# Altium schematic sheet AMRadio.SchDoc(AMRadio)
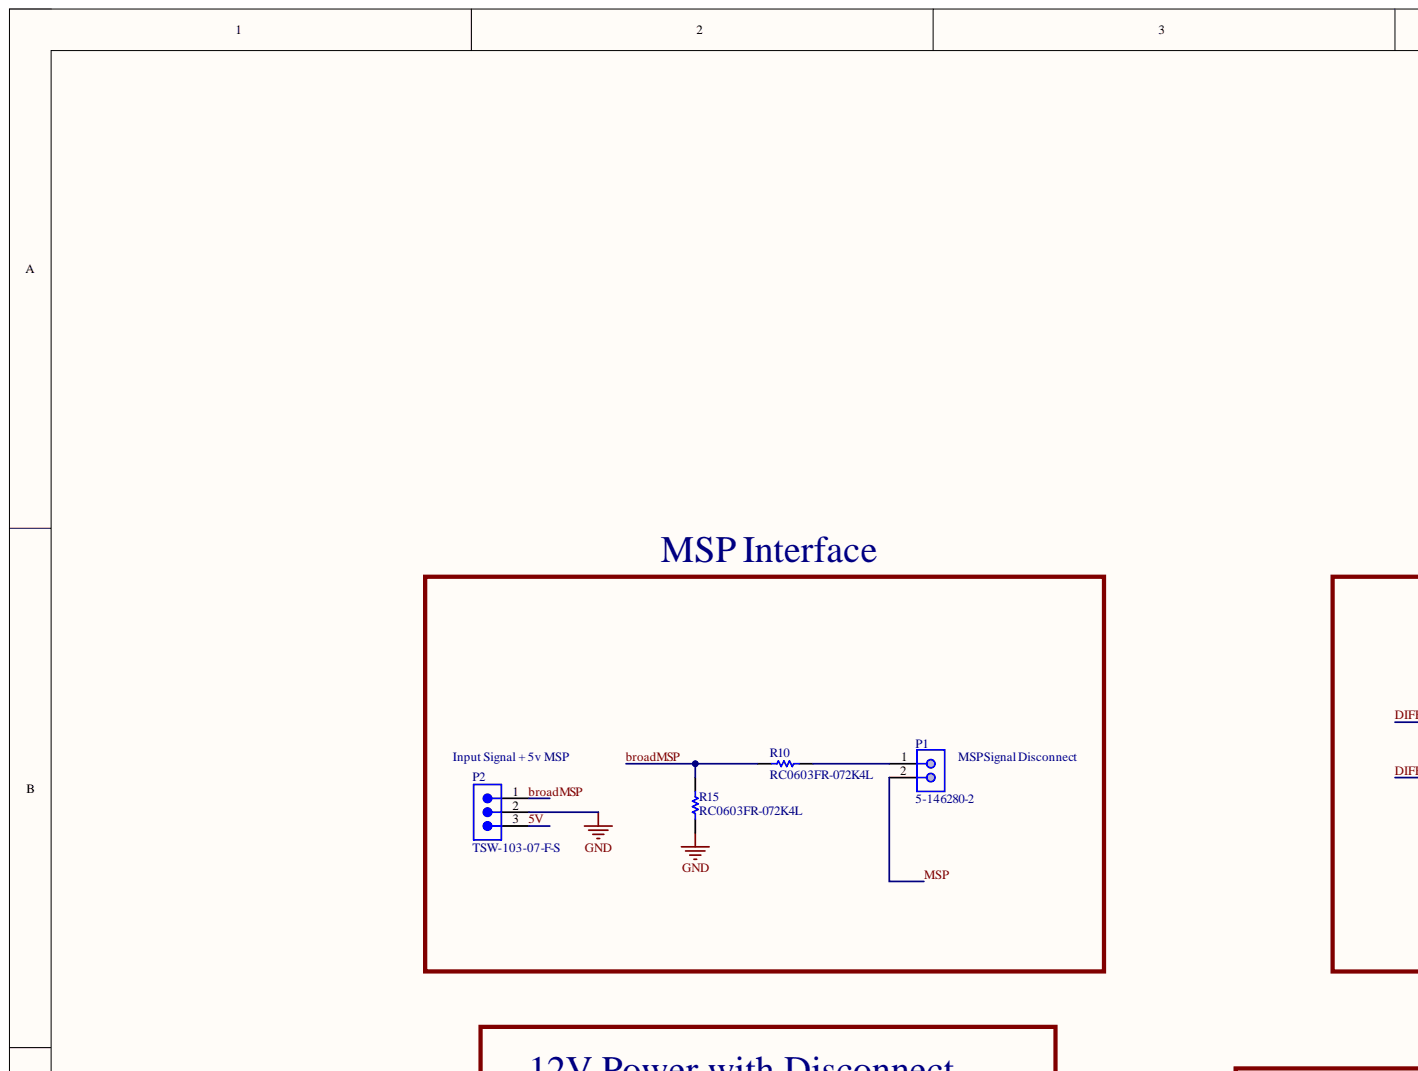
[diagram p0: AMRadio.SchDoc, top left]
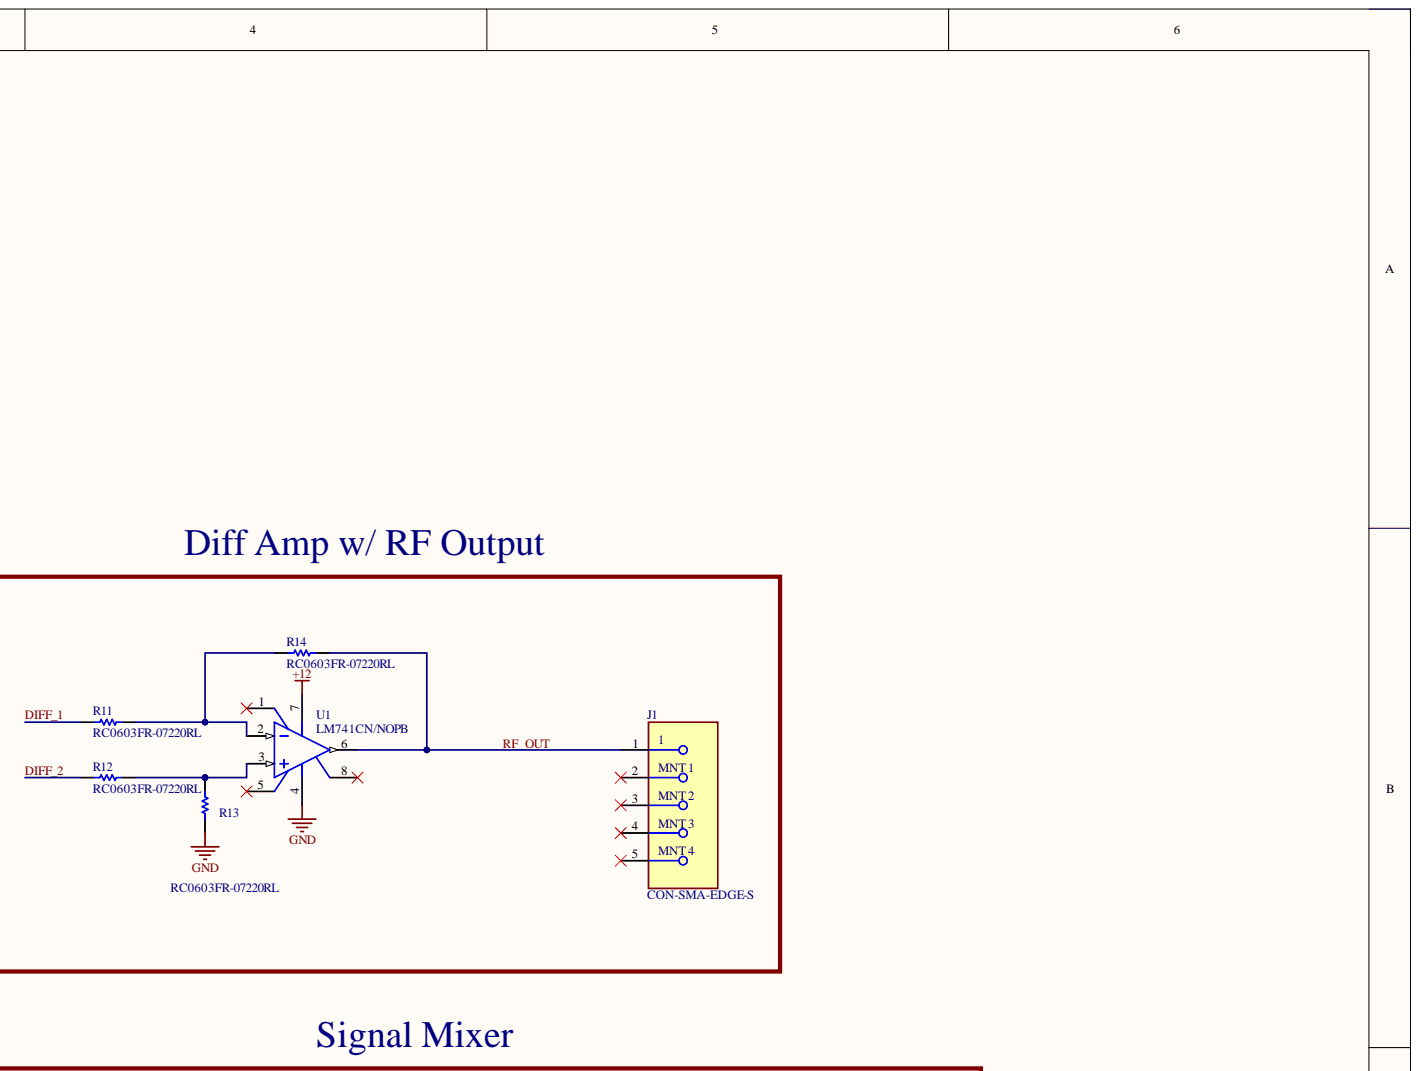
[diagram p1: AMRadio.SchDoc, top right]
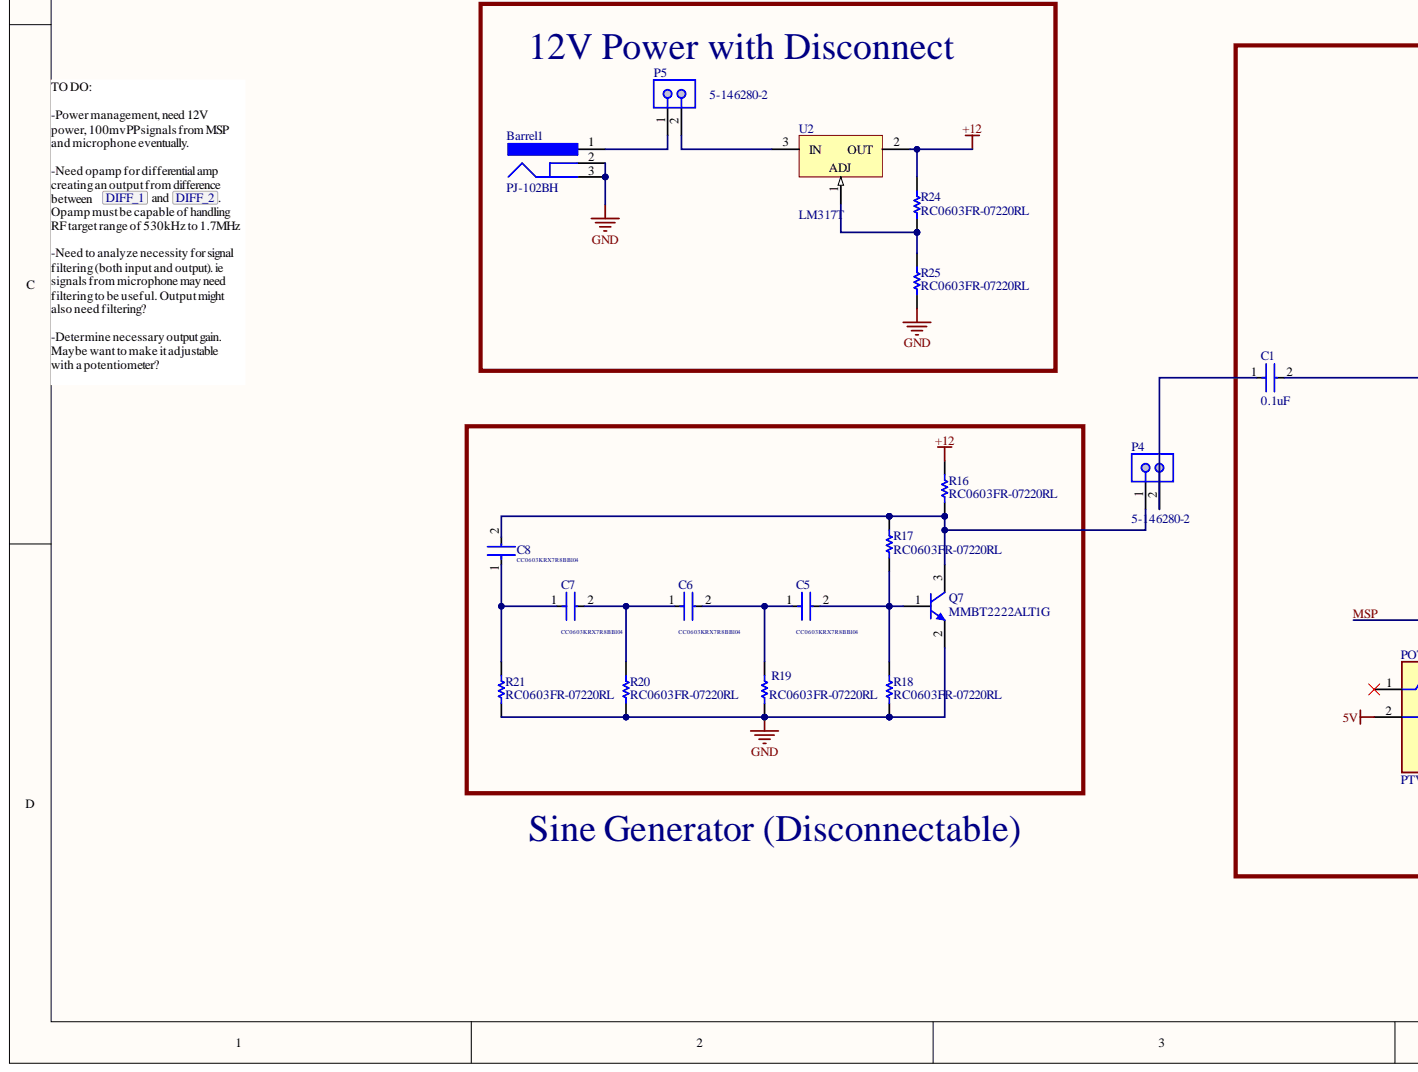
[diagram p2: AMRadio.SchDoc, bottom left]
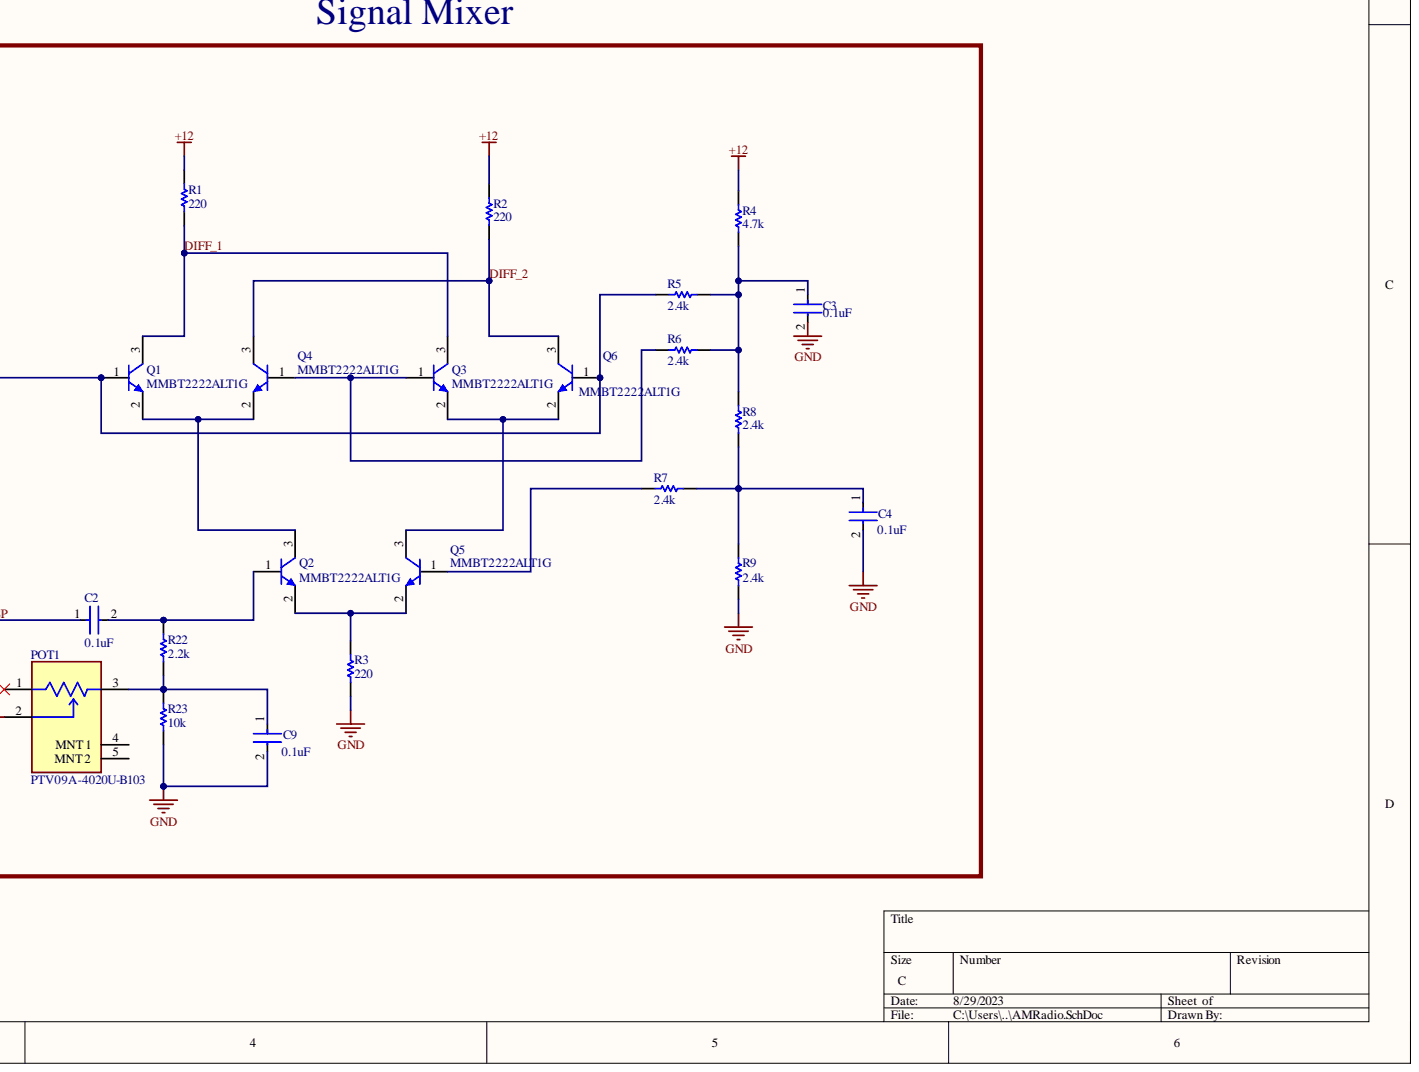
[diagram p3: AMRadio.SchDoc, bottom right]

## Parts
Barrel1  PJ-102BH  [CUI-PJ-102BH_V]
C1  0.1uF  [FP-CC0603-DA-MFG]
C2  0.1uF  [FP-CC0603-DA-MFG]
C3  0.1uF  [FP-CC0603-DA-MFG]
C4  0.1uF  [FP-CC0603-DA-MFG]
C5  CC0603KRX7R8BB104  [FP-CC0603-DA-MFG]
C6  CC0603KRX7R8BB104  [FP-CC0603-DA-MFG]
C7  CC0603KRX7R8BB104  [FP-CC0603-DA-MFG]
C8  CC0603KRX7R8BB104  [FP-CC0603-DA-MFG]
C9  0.1uF  [FP-CC0603-DA-MFG]
J1  CON-SMA-EDGE-S  [FP-CON-SMA-EDGE-S-MFG]
P1  5-146280-2  [TECO-5-146280-2_V]
P2  TSW-103-07-F-S  [SMTC-TSW-103-07-X-S]
P4  5-146280-2  [TECO-5-146280-2_V]
P5  5-146280-2  [TECO-5-146280-2_V]
POT1  PTV09A-4020U-B103  [FP-PTV09A-4-MFG]
Q1  MMBT2222ALT1G  [ONSC-SOT-23-3-318-08_V]
Q2  MMBT2222ALT1G  [ONSC-SOT-23-3-318-08_V]
Q3  MMBT2222ALT1G  [ONSC-SOT-23-3-318-08_V]
Q4  MMBT2222ALT1G  [ONSC-SOT-23-3-318-08_V]
Q5  MMBT2222ALT1G  [ONSC-SOT-23-3-318-08_V]
Q6  MMBT2222ALT1G  [ONSC-SOT-23-3-318-08_V]
Q7  MMBT2222ALT1G  [ONSC-SOT-23-3-318-08_V]
R1  220  [RESC1608X55X25ML10T15]
R2  220  [RESC1608X55X25ML10T15]
R3  220  [RESC1608X55X25ML10T15]
R4  4.7k  [RESC1608X55X25LL10T15]
R5  2.4k  [RESC1608X55X25LL10T15]
R6  2.4k  [RESC1608X55X25LL10T15]
R7  2.4k  [RESC1608X55X25LL10T15]
R8  2.4k  [RESC1608X55X25LL10T15]
R9  2.4k  [RESC1608X55X25LL10T15]
R10  RC0603FR-072K4L  [RESC1608X55X25LL10T15]
R11  RC0603FR-07220RL  [RESC1608X55X25ML10T15]
R12  RC0603FR-07220RL  [RESC1608X55X25ML10T15]
R13  RC0603FR-07220RL  [RESC1608X55X25ML10T15]
R14  RC0603FR-07220RL  [RESC1608X55X25ML10T15]
R15  RC0603FR-072K4L  [RESC1608X55X25LL10T15]
R16  RC0603FR-07220RL  [RESC1608X55X25ML10T15]
R17  RC0603FR-07220RL  [RESC1608X55X25ML10T15]
R18  RC0603FR-07220RL  [RESC1608X55X25ML10T15]
R19  RC0603FR-07220RL  [RESC1608X55X25ML10T15]
R20  RC0603FR-07220RL  [RESC1608X55X25ML10T15]
R21  RC0603FR-07220RL  [RESC1608X55X25ML10T15]
R22  2.2k  [RESC1608X55X25ML10T15]
R23  10k  [RESC1608X55X25ML10T15]
R24  RC0603FR-07220RL  [RESC1608X55X25ML10T15]
R25  RC0603FR-07220RL  [RESC1608X55X25ML10T15]
U1  LM741CN/NOPB  [P0008A]
U2  LM317T  [TO-220]

## Nets
+12: R1.1 R2.1 R4.2 R16.1 R24.1 U1.7 U2.2
GND: Barrel1.2 Barrel1.3 C3.2 C4.2 C9.2 P2.2 Q7.2 R3.2 R9.2 R13.2 R15.1 R18.2 R19.2 R20.2 R21.2 R23.2 R25.2 U1.4
5V: P2.3 POT1.2
broadMSP: P2.1 R10.2 R15.2
DIFF_1: Q1.3 Q3.3 R1.2 R11.1
DIFF_2: Q4.3 Q6.3 R2.2 R12.1
MSP: C2.1 P1.2
RF_OUT: J1.1 R14.2 U1.6
NetBarrel1_1: Barrel1.1 P5.1
NetC1_1: C1.1 P4.2
NetC1_2: C1.2 Q1.1 Q6.1 R5.1
NetC2_2: C2.2 Q2.1 R22.1
NetC3_1: C3.1 R4.1 R5.2 R6.2 R8.1
NetC4_1: C4.1 R7.2 R8.2 R9.1
NetC5_1: C5.1 C6.2 R19.1
NetC5_2: C5.2 Q7.1 R17.2 R18.1
NetC6_1: C6.1 C7.2 R20.1
NetC7_1: C7.1 C8.1 R21.1
NetC8_2: C8.2 P4.1 Q7.3 R16.2 R17.1
NetC9_1: C9.1 POT1.3 R22.2 R23.1
NetP1_1: P1.1 R10.1
NetP5_2: P5.2 U2.3
NetQ1_2: Q1.2 Q2.3 Q4.2
NetQ2_2: Q2.2 Q5.2 R3.1
NetQ3_1: Q3.1 Q4.1 R6.1
NetQ3_2: Q3.2 Q5.3 Q6.2
NetQ5_1: Q5.1 R7.1
NetR11_2: R11.2 R14.1 U1.2
NetR12_2: R12.2 R13.1 U1.3
NetR24_2: R24.2 R25.1 U2.1

Not connected: J1 2 3 4 5; POT1 1 4(MNT_1) 5(MNT_2); U1 1 5 8

## Library data of the parts
Barrel1 = CMP-2000-06901-1: Through Hole Right Angle DC Power Jack, 5 A, 2.5 mm Center Pin, 3 Position, -25 to 85 degC, RoHS, Bulk; Manufacturer: CUIInc.; Brand: CUI; Current Rating: 5A; Inside Contact Diameter: 2.5mm; Insulation Resistance: 100MOhms; Outside Contact Diameter: 6.5mm; Voltage Rating: 24V; Component Kind: Standard; Connector Type: DCPowerJack; Contact Gender: Male; Contact Material: Copper; Contact Plating: Nickel; Datasheet URL: http://www.cui.com/product/resource/pj-102bh.pdf; Datasheet Version: Rev. 1.02, 05/2016; Factory Pack Quantity: 280; Flammability Rating: UL94V-0; Housing Material: PolybutyleneTerephthalate(PBT); Library Name: Altium Content Vault; Manufacturer URL: http://www.cui.com/; Mounting Angle: Right; Mounting Style: ThroughHole; Mounting Technology: ThroughHole; Operating Temperature Range: -25Cto+85C; Package Description: 3-Pin Through Hole Device, Body 14.4 x 9 mm, Height 11 mm; Package Reference: PJ-102BH; Product: Jacks; Product Category: DCPowerConnectors; RoHS: True; Termination Style: ThroughHole
C1-C4 C9 = CMP-03422-000234-1: CAP CER 0.1UF 25V X7R 0603; Manufacturer: YAGEO; Manufacturer Part Number: CC0603KRX7R8BB104; Capacitance: 100 nF; Material: Ceramic; Temperature Coefficient: 15%; Tolerance: 0.1; Value: 100 nF; Automotive: No; Category: Cap; Component Kind: Standard; ComponentLink2Description: Datasheet; ComponentLink2URL: http://www.yageo.com/documents/recent/UPY-GPHC_X7R_6.3V-to-50V_18.pdf; Device Class L1: Passive Components; Device Class L2: Capacitors; Device Class L3: Ceramic Capacitors; Digikey Description: CAP CER 0.1UF 25V X7R 0603; Footprint Url: http://www.yageo.com/exep/pages/download/literatures/UPY-C_GEN_24.pdf; Height: 0.9mm; Lead Free: Yes; Library Name: Altium Content Vault; Mouser Description: CC Series 0603 0.1 uF 25 V ±10% Tolerance X7R SMT Multilayer Ceramic Capacitor; Octopart: https://octopart.com/cc0603krx7r8bb104-yageo-8376534; Package: CC0603-DA; RoHS: TRUE; Temperature: -55°C to +125°C; Temperature Characteristic: X7R; Temperature Range High: +125°C; Temperature Range Low: -55°C; Voltage: 25 V; Voltage Rating: 25 V
C5-C8 = CMP-03422-000234-1: CAP CER 0.1UF 25V X7R 0603; Manufacturer: YAGEO; Capacitance: 100 nF; Material: Ceramic; Temperature Coefficient: 15%; Tolerance: 0.1; Value: 100 nF; Automotive: No; Category: Cap; Component Kind: Standard; ComponentLink2Description: Datasheet; ComponentLink2URL: http://www.yageo.com/documents/recent/UPY-GPHC_X7R_6.3V-to-50V_18.pdf; Device Class L1: Passive Components; Device Class L2: Capacitors; Device Class L3: Ceramic Capacitors; Digikey Description: CAP CER 0.1UF 25V X7R 0603; Footprint Url: http://www.yageo.com/exep/pages/download/literatures/UPY-C_GEN_24.pdf; Height: 0.9mm; Lead Free: Yes; Library Name: Altium Content Vault; Manufacturer Part Number: CC0603KRX7R8BB104; Mouser Description: CC Series 0603 0.1 uF 25 V ±10% Tolerance X7R SMT Multilayer Ceramic Capacitor; Octopart: https://octopart.com/cc0603krx7r8bb104-yageo-8376534; Package: CC0603-DA; RoHS: TRUE; Temperature: -55°C to +125°C; Temperature Characteristic: X7R; Temperature Range High: +125°C; Temperature Range Low: -55°C; Voltage: 25 V; Voltage Rating: 25 V
J1 = CMP-204357-000001-1: CONN SMA JACK STR EDGE MNT; Manufacturer: RF Solutions Ltd.; Conn Orientation: Right Angle; Frequency: 18GHz; Impedance: 50Ω; Is Female: yes; Number Of Contacts: 1; Shielding: true; Automotive: No; Category: Conn; Component Kind: Standard; ComponentLink2Description: Datasheet; ComponentLink2URL: https://www.rfsolutions.co.uk/downloads/1498648656DS-CON-EDGE-SMA-4.pdf; Contact Material: Gold; Device Class L1: Connectors; Device Class L2: RF / Coaxial Connectors; Device Class L3: unset; Digikey Description: CONN SMA JACK STR EDGE MNT; Footprint Url: https://forum.upverter.com/uploads/default/original/3X/b/e/be75b907af57498775929d6d9099689c472e0307.pdf; Height: 6.35mm; Lead Free: Yes; Library Name: Altium Content Vault; Manufacturer Part Number: CON-SMA-EDGE-S; Octopart: https://octopart.com/con-sma-edge-s-rf+solutions-70967450; Package: CONN_JACK; RoHS: TRUE
P1 P4 P5 = CMP-2000-07492-1: Male Header, Pitch 2.54 mm, 1 x 2 Position, Height 8.21 mm, Tail Length 3.05 mm, -65 to 105 degC, RoHS, Bulk; Manufacturer: TE Connectivity; Approvals: CSA; Board Standoff: No(Without); Case\/Package: 100; Current Rating: 3A; High Speed Serial Data Connector: No; High Temperature Housing: Yes; Mating Post Length: 0.23inch; Max Current Rating: 5A; Number of Contacts: 2; PCB Mount Retention: Without; PCB Mounting Orientation: Vertical; PCB Thickness: 0.063inch; Pitch: 2.54mm; Colour: Black; Component Kind: Standard; Connector Type: Header; Contact Gender: Male; Contact Material: CopperAlloy; Contact Mating Length: 0.23inch; Contact Plating: Matte; Contact Protection: No(Without); Contact Shape: Square; Datasheet URL: http://www.te.com/commerce/DocumentDelivery/DDEController?Action=showdoc&DocId=Customer+Drawing%7F146280%7FH1%7Fpdf%7FEnglish%7FENG_CD_146280_H1.pdf%7F5-146280-2; Datasheet Version: Rev. H1, 08/2016; Depth: 2.34mm; Flammability Rating: UL94V-0; Gasket: No(Without); Housing Colour: Black; Housing Material: Polymer; Keyed: No; Length: 4.67mm; Library Name: Altium Content Vault; Manufacturer URL: http://www.te.com/; Mating Alignment: No(Without); Mating Connector Lock: No(Without); Max Operating Temperature: 105degC; Min Operating Temperature: -65degC; Mount: ThroughHole; Mounting Technology: ThroughHole; Number of Positions: 2; Number of Rows: 1; Orientation: Straight; Package Description: Male Header, Pitch 2.54 mm, 1 x 2 Position, Height 8.21 mm, Tail Length 3.05 mm; Package Quantity: 500; Package Reference: 5-146280-2; Packaging: Carton; Panel Mount Retention: No(Without); Radiation Hardening: No; RoHSCompliant: true; Row Spacing: 2.54mm; Selectively Loaded: No(Without); Stabilizers: No(Without); Termination: ThroughHole; Termination Post Length: 0.12inch
P2 = CMP-1024-00331-1: 0.025" SQ Post Header, Through-hole, Vertical, -55 to 125 degC, 2.54 mm Pitch, 3-Pin, Male, RoHS; Manufacturer: Samtec; Pitch: 2.54 mm; Component Kind: Standard; ComponentLink1Description: Manufacturer URL; ComponentLink1URL: http://www.samtec.com; ComponentLink2Description: Datasheet; ComponentLink2URL: http://www.samtec.com/documents/webfiles/pdf/TSW_TH.PDF; ComponentLink3Description: Package Specification; ComponentLink3URL: http://www.samtec.com/documents/webfiles/cpdf/TSW-XXX-XX-XXX-X-XX-XXX-MKT.pdf; DatasheetVersion: F-214; Library Name: Altium Content Vault; Mounting Technology: Through-hole; Orientation: Vertical; PackageDescription: .025[0.64] SQ. Post Terminal Strip Assembly; PackageReference: TSW-103-07-X-S; PackageVersion: DM, 8/1988; PartNumber: TSW-103-07-F-S; RoHS: True; Temperature Range: -55 to 125 degC
POT1 = CMP-07234-000013-1: POT 10K OHM 1/20W CARBON LINEAR; Manufacturer: Bourns Inc.; Power Rating: 0.05W; Resistance: 10kΩ; Tolerance: 20%; Value: 10kΩ; Voltage Rating: 50V; Automotive: No; Category: Res; Component Kind: Standard; ComponentLink2Description: Datasheet; ComponentLink2URL: https://www.bourns.com/docs/product-datasheets/ptv09.pdf?sfvrsn=5e1089f1_12; Composition: Carbon; Device Class L1: Passive Components; Device Class L2: Resistors; Device Class L3: Variable Resistors and Potentiometers; Digikey Description: POT 10K OHM 1/20W CARBON LINEAR; Height: 20.5mm; Lead Free: Yes; Library Name: Altium Content Vault; Manufacturer Part Number: PTV09A-4020U-B103; Mouser Description: Potentiometers 10K LINEAR 20%; Octopart: https://octopart.com/ptv09a-4020u-b103-bourns-1878033; Package: PTV09A-4; RoHS: TRUE; Series: PTV09; Temperature: -10°C to +50°C; Temperature Range High: +50°C; Temperature Range Low: -10°C
Q1-Q7 = CMP-1048-00538-1: General Purpose Transistor, NPN Silicon, 3-Pin SOT-23, Pb-Free, Tape and Reel; Manufacturer: On Semiconductor; fT Min (MHz): 300; IC Continuous (A): 0.6; Component Kind: Standard; ComponentLink1Description: Manufacturer URL; ComponentLink1URL: http://www.onsemi.com/; ComponentLink2Description: Datasheet; ComponentLink2URL: http://www.onsemi.cn/pub_link/Collateral/MMBT2222LT1-D.PDF; ComponentLink3Description: Package Specification; ComponentLink3URL: http://www.onsemi.cn/pub_link/Collateral/318-08.PDF; DatasheetVersion: Rev. 10; Library Name: Altium Content Vault; Mounting Technology: Surface Mount; PackageDescription: 3-Pin Small Outline Transistor, Body 2.92 x 1.3 mm, Pitch 0.95 mm; PackageReference: SOT-23-3-318-08; PackageVersion: Rev. AP, 11/2009; Packing: Tape and Reel; PartNumber: MMBT2222ALT1G; Polarity: NPN; RoHS: TRUE
R1-R3 R11-R14 R16-R23 = CMP-1659-00018-2: General Purpose Chip Resistors, 220 Ohm, +/- 1%, -55 to 155 degC, 0603 (1608 Metric), RoHS, Tape and Reel; Component Kind: Standard; ComponentLink1Description: Manufacturer URL; ComponentLink1URL: http://www.yageo.com/; ComponentLink2Description: Datasheet; ComponentLink2URL: https://datasheet.ciiva.com/11808/0900766b80f96399-11808136.pdf; DatasheetVersion: Rev. 4, 04/2009; Library Name: Altium Content Vault; PackageDescription: 2-Pin Surface Mount Device, Body 1.6 x 0.8 mm; PackageReference: 0603; RoHSCompliant: false
R4 R10 R15 = CMP-2000-06935-1: Chip Resistor, 4.7 kOhm, +/- 1%, 0.1 W, -55 to 155 degC, 0603 (1608 Metric), RoHS, Tape and Reel; Manufacturer: Yageo; Catalog Drawings: ThickFilmResistorSide; EDA / CAD Models: DownloadfromAcceleratedDesigns; HTML Datasheet: RCSeries,LSuffixDatasheet.html; Kits: PHH4-KIT-ND-RESKIT1K-9.76K1/10W5700PCS; Online Catalog: RC0603Series; Other Names: 311-2.40KHRCT; PCN Other: RC/AC0603/0805/120622/Jan/2013; Power (Watts): 0.1W,1/10W; Resistance (Ohms): 2.4k; Temperature Coefficient: ±100ppm/°C; Category: Resistors; Component Kind: Standard; Composition: ThickFilm; Datasheet URL: http://www.yageo.com/documents/recent/PYu-RC_Group_51_RoHS_L_7.pdf; Datasheet Version: Rev. 7, 05/2017; Family: ChipResistor-SurfaceMount; Features: MoistureResistant; Height: 0.022"(0.55mm); Library Name: Altium Content Vault; Manufacturer URL: http://www.yageo.com/; Mounting Technology: SurfaceMount; Number of Terminations: 2; Operating Temperature: -55°C~155°C; Package / Case: 0603(1608Metric); Package Description: 2-Pin Surface Mount Device, Body 1.6 x 0.8 mm; Package Reference: 0603; Packaging: CutTape(CT); Product Training Modules: ChipResistor; Series: RC; Size / Dimension: 0.063"Lx0.031"W(1.60mmx0.80mm); Standard Package: 1; Supplier Device Package: 0603; Tolerance: ±1%
R5-R9 = CMP-2000-06935-1: Chip Resistor, 2.4 kOhm, +/- 1%, 0.1 W, -55 to 155 degC, 0603 (1608 Metric), RoHS, Tape and Reel; Manufacturer: Yageo; Catalog Drawings: ThickFilmResistorSide; EDA / CAD Models: DownloadfromAcceleratedDesigns; HTML Datasheet: RCSeries,LSuffixDatasheet.html; Kits: PHH4-KIT-ND-RESKIT1K-9.76K1/10W5700PCS; Online Catalog: RC0603Series; Other Names: 311-2.40KHRCT; PCN Other: RC/AC0603/0805/120622/Jan/2013; Power (Watts): 0.1W,1/10W; Temperature Coefficient: ±100ppm/°C; Category: Resistors; Component Kind: Standard; Composition: ThickFilm; Datasheet URL: http://www.yageo.com/documents/recent/PYu-RC_Group_51_RoHS_L_7.pdf; Datasheet Version: Rev. 7, 05/2017; Family: ChipResistor-SurfaceMount; Features: MoistureResistant; Height: 0.022"(0.55mm); Library Name: Altium Content Vault; Manufacturer URL: http://www.yageo.com/; Mounting Technology: SurfaceMount; Number of Terminations: 2; Operating Temperature: -55°C~155°C; Package / Case: 0603(1608Metric); Package Description: 2-Pin Surface Mount Device, Body 1.6 x 0.8 mm; Package Reference: 0603; Packaging: CutTape(CT); Product Training Modules: ChipResistor; Resistance (Ohms): 2.4k; Series: RC; Size / Dimension: 0.063"Lx0.031"W(1.60mmx0.80mm); Standard Package: 1; Supplier Device Package: 0603; Tolerance: ±1%
R24 = CMP-1659-00018-2: General Purpose Chip Resistors, 560 Ohm, +/- 1%, -55 to 155 degC, 0603 (1608 Metric), RoHS, Tape and Reel; Component Kind: Standard; ComponentLink1Description: Manufacturer URL; ComponentLink1URL: http://www.yageo.com/; ComponentLink2Description: Datasheet; ComponentLink2URL: https://datasheet.ciiva.com/11808/0900766b80f96399-11808136.pdf; DatasheetVersion: Rev. 4, 04/2009; Library Name: Altium Content Vault; PackageDescription: 2-Pin Surface Mount Device, Body 1.6 x 0.8 mm; PackageReference: 0603; RoHSCompliant: false
R25 = CMP-1659-00018-2: General Purpose Chip Resistors, 5000 Ohm, +/- 1%, -55 to 155 degC, 0603 (1608 Metric), RoHS, Tape and Reel; Component Kind: Standard; ComponentLink1Description: Manufacturer URL; ComponentLink1URL: http://www.yageo.com/; ComponentLink2Description: Datasheet; ComponentLink2URL: https://datasheet.ciiva.com/11808/0900766b80f96399-11808136.pdf; DatasheetVersion: Rev. 4, 04/2009; Library Name: Altium Content Vault; PackageDescription: 2-Pin Surface Mount Device, Body 1.6 x 0.8 mm; PackageReference: 0603; RoHSCompliant: false
U1 = CMP-0055-00162-3: Operational Amplifier, 8-pin MDIP, Pb-Free; Manufacturer: Texas Instruments; CompType: Amplifiers; PackageTopMarking: NSUZXYTTE#*LM*741CN; PowerWise: N; Code_JEDEC: MS-001-BA; Component Kind: Standard; ComponentLink1Description: Datasheet URL; ComponentLink1URL: http://www.ti.com/general/docs/lit/getliterature.tsp?genericPartNumber=LM741&fileType=pdf; Library Name: Altium Content Vault; Package Reference: P0008A; PackageDescription: MDIP, .365 x .250 x .130in, 8 Lead, .100in Pitch; PinCount: 8; RoHS: Y
U2 = CMP-0244-00444-1: 1.5A, 1.2V to 37V Adjustable Voltage Regulator, 3-Pin TO-220; Component Kind: Standard; ComponentLink1Description: Manufacturer URL; ComponentLink1URL: http://www.st.com; ComponentLink2Description: Datasheet; ComponentLink2URL: http://www.st.com/internet/com/TECHNICAL_RESOURCES/TECHNICAL_LITERATURE/DATASHEET/CD00000455.pdf; ComponentLink3Description: Package Specification; ComponentLink3URL: http://www.st.com/internet/com/TECHNICAL_RESOURCES/TECHNICAL_LITERATURE/PACKAGE_INFORMATION/CD00004649.pdf; DatasheetVersion: 1/11/2011; Library Name: Altium Content Vault; PackageDescription: TO, Thru-Hole, Vertical, Heatsink Mounted; 3 In-Line Leads; Pitch 2.54 mm; PackageReference: TO-220; PackageVersion: 2011
